# Altium schematic sheet KS2E-IMD-AMS-LATCH.SchDoc(KS2E-IMD-AMS-LATCH)
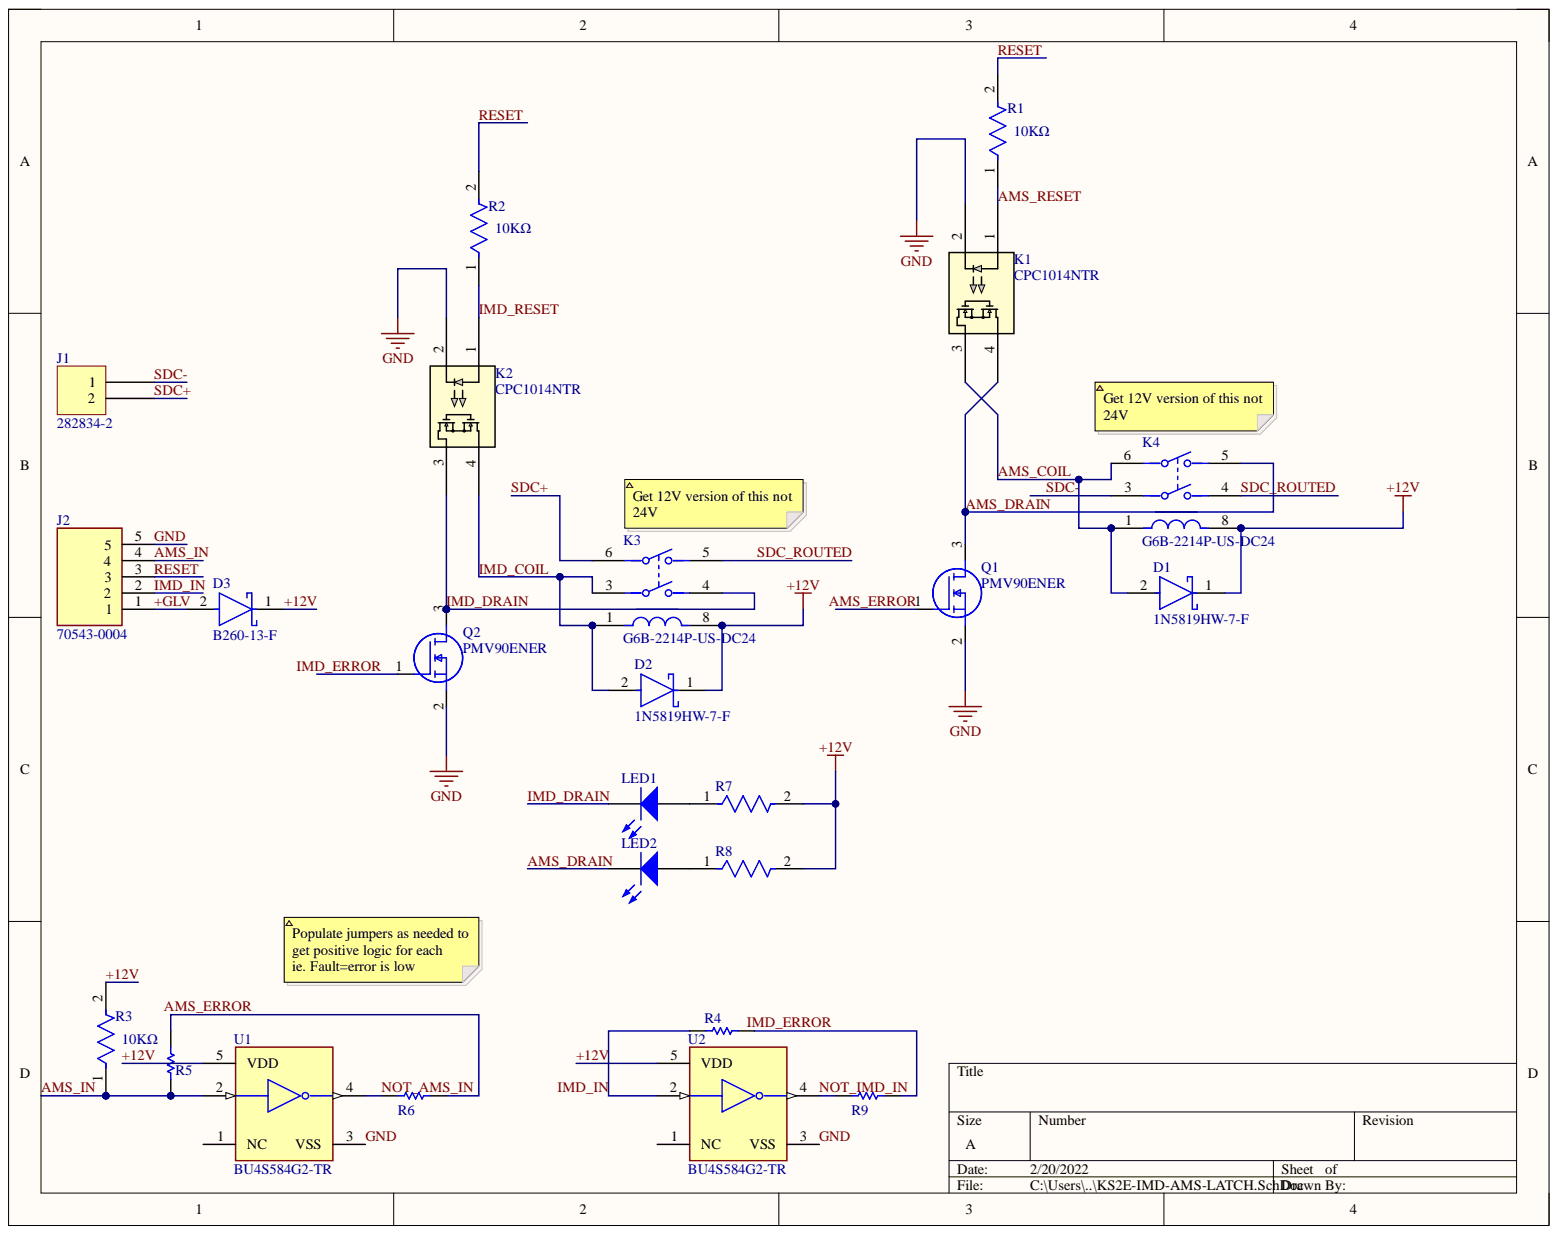
[diagram p0: KS2E-IMD-AMS-LATCH.SchDoc]

## Parts
D1  1N5819HW-7-F  [FP-SOD123-IPC_A]
D2  1N5819HW-7-F  [FP-SOD123-IPC_A]
D3  B260-13-F  [FP-SMB-MFG]
J1  282834-2  [TE 282834-2]
J2  70543-0004  [SHDR5W64P0X254_1X5_1525X660X1181P]
K1  CPC1014NTR  [CPC1014N_IXY]
K2  CPC1014NTR  [CPC1014N_IXY]
K3  G6B-2214P-US-DC24  [FP-G6B-2214P-US-DC24-MFG]
K4  G6B-2214P-US-DC24  [FP-G6B-2214P-US-DC24-MFG]
LED1  150080SS75000  [0805_A]  (value not drawn)
LED2  150080SS75000  [0805_A]  (value not drawn)
Q1  PMV90ENER  [SOT95P230X110-3N]
Q2  PMV90ENER  [SOT95P230X110-3N]
R1  value 10KΩ  Resistance: 10KΩ  [FP-RP73P-2A-MFG]  (hidden comment: RP73PF2A10KBTDF)
R2  value 10KΩ  Resistance: 10KΩ  [FP-RP73P-2A-MFG]  (hidden comment: RP73PF2A10KBTDF)
R3  value 10KΩ  Resistance: 10KΩ  [FP-RP73P-2A-MFG]  (hidden comment: RP73PF2A10KBTDF)
R4  CRG0805ZR  [RESC2013X65X40LL15T20]  (value not drawn)
R5  CRG0805ZR  [RESC2013X65X40LL15T20]  (value not drawn)
R6  CRG0805ZR  [RESC2013X65X40LL15T20]  (value not drawn)
R7  CRCW1206500RJNEA  [FP-CRCW1206-e3-IPC_B]  (value not drawn)
R8  CRCW1206500RJNEA  [FP-CRCW1206-e3-IPC_B]  (value not drawn)
R9  CRG0805ZR  [RESC2013X65X40LL15T20]  (value not drawn)
U1  BU4S584G2-TR  [FP-SSOP5-MFG]
U2  BU4S584G2-TR  [FP-SSOP5-MFG]

## Nets
+12V: D1.1 D2.1 D3.1 K3.8 K4.8 R3.2 R7.2 R8.2 U1.5(VDD) U2.5(VDD)
+GLV: D3.2 J2.1
AMS_COIL: D1.2 K1.3 K4.1 K4.6
AMS_DRAIN: K1.4 K4.5 LED2.1 Q1.3
AMS_ERROR: Q1.1 R5.2 R6.2
AMS_IN: J2.4 R3.1 R5.1 U1.2
AMS_RESET: K1.1 R1.1
GND: J2.5 K1.2 K2.2 Q1.2 Q2.2 U1.3(VSS) U2.3(VSS)
IMD_COIL: D2.2 K2.4 K3.1 K3.3
IMD_DRAIN: K2.3 K3.4 LED1.1 Q2.3
IMD_ERROR: Q2.1 R4.2 R9.2
IMD_IN: J2.2 R4.1 U2.2
IMD_RESET: K2.1 R2.1
NOT_AMS_IN: R6.1 U1.4
NOT_IMD_IN: R9.1 U2.4
RESET: J2.3 R1.2 R2.2
SDC+: J1.2 K3.6
SDC-: J1.1 K4.3
SDC_ROUTED: K3.5 K4.4
NetLED1_2: LED1.2 R7.1
NetLED2_2: LED2.2 R8.1

Not connected: U1 1(NC); U2 1(NC)

## Library data of the parts
D1 D2 = CMP-0303-00003-3: DIODE SCHOTTKY 40V 1A SOD123; Capacitance: 110pF; Element Configuration: Single; Forward Voltage: 450mV; Max Forward Surge Current (Ifsm): 25A; Max Power Dissipation: 450mW; Peak Reverse Current: 50uA; Polarity: Standard; Average Rectified Current: 1A; Case/Package: SOD-123-2; Component Kind: Standard; Contact Plating: Tin; Current Rating: 1A; Forward Current: 1A; Height: 1.25mm; Lead Free: Lead Free; Length: 2.85mm; Library Name: Altium Content Vault; Max Operating Temperature: 125°C; Max Repetitive Reverse Voltage (Vrrm): 40V; Max Reverse Voltage (DC): 40V; Min Operating Temperature: -65°C; Number of Pins: 2; Output Current: 1A; Package Quantity: 3000; Packaging: Tape and Reel; Peak Non-Repetitive Surge Current: 25A; Radiation Hardening: No; REACH SVHC: No SVHC; Termination: SMD/SMT; Voltage Rating (DC): 40V; Weight: 0.000353oz; Width: 1.7mm
D3 = CMP-12790-000014-1: DIODE SCHOTTKY 60V 2A SMB; Manufacturer: Diodes Inc.; Capacitance: 200pF; Max Junction Temp: +150°C; Max Surge Forward Current: 50A; Peak Reverse Current: 0.5mA; Standoff Height: 0.05mm; Value: 200pF; Automotive: No; Category: Diode; Component Kind: Standard; ComponentLink2Description: Datasheet; ComponentLink2URL: https://www.diodes.com/assets/Datasheets/ds13004.pdf; Device Class L1: Discrete Semiconductors; Device Class L2: Diodes; Device Class L3: Schottky Diodes; Digikey Description: DIODE SCHOTTKY 60V 2A SMB; Footprint Url: https://www.diodes.com/assets/Package-Files/SMB.pdf; Forward Current: 2A; Height: 2.5mm; Lead Free: Yes; Library Name: Altium Content Vault; Manufacturer Part Number: B260-13-F; Max Repetitive Reverse Voltage: 60V; Mouser Description: Schottky Diodes & Rectifiers 60V 2A; Octopart: https://octopart.com/b260-13-f-diodes+inc.-325789; Package: SMB; Reverse Voltage: 60V; RoHS: TRUE; Temperature: -65°C to +150°C; Temperature Range High: +150°C; Temperature Range Low: -65°C
J1 = 282834-2: TERM BLK 2P SIDE ENT 2.54MM PCB; Manufacturer: TE Connectivity AMP Connectors; Current: 10A; Database Table Name: Connectors - Terminal Blocks - Wire to Board; Mating Orientation: Horizontal with Board; Number of Levels: 1; Pitch: 0.100" (2.54mm); Positions Per Level: 2; Voltage: 150V; Wire Gauge: 16-30 AWG; Wire Termination: Screw - Rising Cage Clamp; Color: Green; Component Kind: Standard; ComponentLink1Description: Datasheet; ComponentLink1URL: https://www.te.com/commerce/DocumentDelivery/DDEController?Action=srchrtrv&DocNm=282834&DocType=Customer+Drawing&DocLang=English; ComponentLink2Description: DigiKey Link; ComponentLink2URL: http://digikey.com/product-detail/en/te-connectivity-amp-connectors/282834-2/A98333-ND/1150135; LastUpdated: 1/15/2020 4:15:38 AM; Library Name: Celestial Altium Library - altium_library.DbLib; Lifecycle Status: Active; Minimum Order: 1; Mounting Type: Through Hole; Packaging: Bulk; Part Number: 282834-2; Part Status: Active; Price: 0.88; Series: Buchanan; Supplier 1: DigiKey; Supplier Part Number 1: A98333-ND
J2 = 70543-0004: Connector; Manufacturer_Name: Molex; Component Kind: Standard; Datasheet Link: https://componentsearchengine.com/Datasheets/2/70543-0004.pdf; Height: 11.81mm; Manufacturer_Part_Number: 70543-0004; Mouser Part Number: 538-70543-0004; Mouser Price/Stock: https://www.mouser.co.uk/ProductDetail/Molex/70543-0004?qs=ZwgtpdmWYYQRmWofVdhxiw%3D%3D
K1 K2 = CPC1014NTR: No Description Available; Mfr_Name: Littelfuse Inc; Component Kind: Standard; Digi-Key_Part_Number_1: CLA314TR-ND; Digi-Key_Part_Number_2: CLA314CT-ND; Digi-Key_Part_Number_3: CLA314DKR-ND; Library Name: CPC1014NTR.IntLib; Manufacturer_Part_Number: CPC1014NTR; RefDes: RefDes; Type: DEV; Copyright: Copyright (C) 2022 Ultra Librarian. All rights reserved.
K3 K4 = CMP-08822-000243-1: RELAY GEN PURPOSE DPST 5A 24V; Approvals: UL; Coil Current: 8.3mA; Coil Power: 300mW; Coil Resistance: 2.88kR; Coil Type: Non-Latching; Max Voltage Rating (AC): 380V; Max Voltage Rating (DC): 125V; Operate Time: 10ms; Power Consumption: 200mW; Relay Type: General Purpose; Case/Package: DIP; Coil Voltage (DC): 24V; Component Kind: Standard; Contact Current Rating: 5A; Contact Material: Silver; Current Rating: 5A; Depth: 11mm; Height: 10mm; Lead Free: Lead Free; Length: 20mm; Library Name: Altium Content Vault; Max Current Rating: 5A; Max Operating Temperature: 70°C; Min Operating Temperature: -25°C; Mount: Through Hole; Number of Pins: 6; Package Quantity: 100; Packaging: Bulk; Radiation Hardening: No; Release Time: 10ms; Series: G6B; Termination: PC Pin; Throw Configuration: DPST; Voltage Rating (AC): 380V; Voltage Rating (DC): 125V; Weight: 0.141096oz; Width: 10mm
LED1 LED2 = CMP-1426-00010-1: SMD mono-color Chip LED, WL-SMCW, Super Red; Manufacturer: Wuerth Elektronik; Color: Super Red; Component Kind: Standard; ComponentLink1Description: Manufacturer URL; ComponentLink1URL: http://www.we-online.com; ComponentLink2Description: Datasheet; ComponentLink2URL: http://katalog.we-online.com/led/datasheet/150080SS75000.pdf; Library Name: Altium Content Vault; PackageReference: 0805_A; PartNumber: 150080SS75000
Q1 Q2 = PMV90ENER: MOSFET (N-Channel); Manufacturer_Name: Nexperia; Arrow Part Number: PMV90ENER; Arrow Price/Stock: https://www.arrow.com/en/products/pmv90ener/nexperia; Component Kind: Standard; Datasheet Link: https://assets.nexperia.com/documents/data-sheet/PMV90ENE.pdf; Height: 1.1mm; Manufacturer_Part_Number: PMV90ENER; Mouser Part Number: 771-PMV90ENER; Mouser Price/Stock: https://www.mouser.co.uk/ProductDetail/Nexperia/PMV90ENER?qs=gH1BdSMZm8U46al1TitK1w%3D%3D
R1-R3 = CMP-03211-008023-1: RP73P 0805 10KΩ 0.25W 25ppm/°C 0.10% 150V; Manufacturer: TE Connectivity; Tolerance: 0.001; Automotive: No; Category: Res; Component Kind: Standard; ComponentLink2Description: Datasheet; ComponentLink2URL: https://www.te.com/commerce/DocumentDelivery/DDEController?Action=srchrtrv&DocNm=1773272&DocType=DS&DocLang=English; Composition: Thin Film; Device Class L1: Passive Components; Device Class L2: Resistors; Device Class L3: Chip SMD Resistors; Height: 0.65mm; Ipc Land Pattern Name: RESC200125X55; Lead Free: Yes; Library Name: Altium Content Vault; Manufacturer Part Number: RP73PF2A10KBTDF; Octopart: https://octopart.com/2176093-5-te+connectivity-46441071; Package: RP73P-2A; Power Rating: 0.25W; RoHS: TRUE; Series: RP73P; Temperature: -55°C to +155°C; Temperature Coefficient: 25ppm/°C; Temperature Range High: +155°C; Temperature Range Low: -55°C; Voltage Rating: 150V
R4-R6 R9 = CMP-2000-07514-1: Chip Resistor, 0 Ohm, 0.125 W, -55 to 125 degC, 0805 (2012 Metric), RoHS, Tape and Reel; Manufacturer: TE Connectivity; Case\/Package: 0805; Number of Resistors: 1; Power Rating: 125mW; Resistance: 0mOhm; Tolerance: 0%; Voltage Rating: 150V; Case Code (Imperial): 0805; Case Code (Metric): 2012; Component Kind: Standard; Composition: ThickFilm; Datasheet URL: www.te.com/commerce/DocumentDelivery/DDEController?Action=showdoc&DocId=Customer+Drawing%7F1623401%7FBA%7Fpdf%7FEnglish%7FENG_CD_1623401_BA.pdf%7F1623401-1; Datasheet Version: Rev. BA, 12/2009; Depth: 1.25mm; ELV: Compliant; Height: 0.55mm; Length: 2mm; Library Name: Altium Content Vault; Manufacturer URL: http://www.te.com/; Max Operating Temperature: 125degC; Min Operating Temperature: -55degC; Mount: SurfaceMount; Mounting Technology: SurfaceMount; Number of Terminations: 2; Package Description: 2-Pin Surface Mount Device, Body 2 x 1.25 mm; Package Quantity: 5000; Package Reference: RESC2013X65X40L15T20; Packaging: TapeandReel; Radiation Hardening: No; RoHSCompliant: true; Termination: Solder; Weight: 0.000194oz; Width: 1.25mm
R7 R8 = CMP-02407-007735-1: RES Thick Film, 500Ω, 5%, 0.25W, 200ppm/°C, 1206; Resistance: 500R; Case/Package: 1206; Component Kind: Standard; Composition: Thick Film; Contact Plating: Tin; Lead Free: Lead Free; Library Name: Altium Content Vault; Max Operating Temperature: 155°C; Min Operating Temperature: -55°C; Packaging: Tape and Reel; Radiation Hardening: No; Temperature Coefficient: 200ppm/°C; Tolerance: 5%
U1 U2 = CMP-08923-000001-1: IC INVERTER SCHMITT 1CH 5SSOP; Manufacturer: Rohm; Logic Function Desc: Inverting; Max Junction Temp: +150°C; Max Supply Voltage: 16V; Min Supply Voltage: 3V; Number Of Circuits: 1; Propagation Delay: 50ns; Quiescent Current: 0.2-1uA; Standoff Height: 0mm; Automotive: No; Category: IC; Component Kind: Standard; ComponentLink2Description: Datasheet; ComponentLink2URL: https://www.rohm.com/datasheet/BU4S584G2/bu4s584g2-e; Device Class L1: Integrated Circuits (ICs); Device Class L2: Logic ICs; Device Class L3: Logic Gates; Digikey Description: IC INVERTER SCHMITT 1CH 5SSOP; Footprint Url: https://d1d2qsbl8m0m72.cloudfront.net/en/techdata_basic/ic/package/ssop5_1-e.pdf; Height: 1.25mm; Ipc Land Pattern Name: SOT95P280X125-5; Lead Free: Yes; Library Name: Altium Content Vault; Manufacturer Part Number: BU4S584G2-TR; Mouser Description: Inverters IC SCHMITT TRIGGER SINGLE; Number Of Inputs: 1; Number Of Outputs: 1; Octopart: https://octopart.com/bu4s584g2-tr-rohm-466637; Package: SSOP5; RoHS: TRUE; Temperature: -40°C to +85°C; Temperature Range High: +85°C; Temperature Range Low: -40°C
